# Altium schematic sheet S4V_ToF_Sensor_Board_V1.0_Schematic.SchDoc
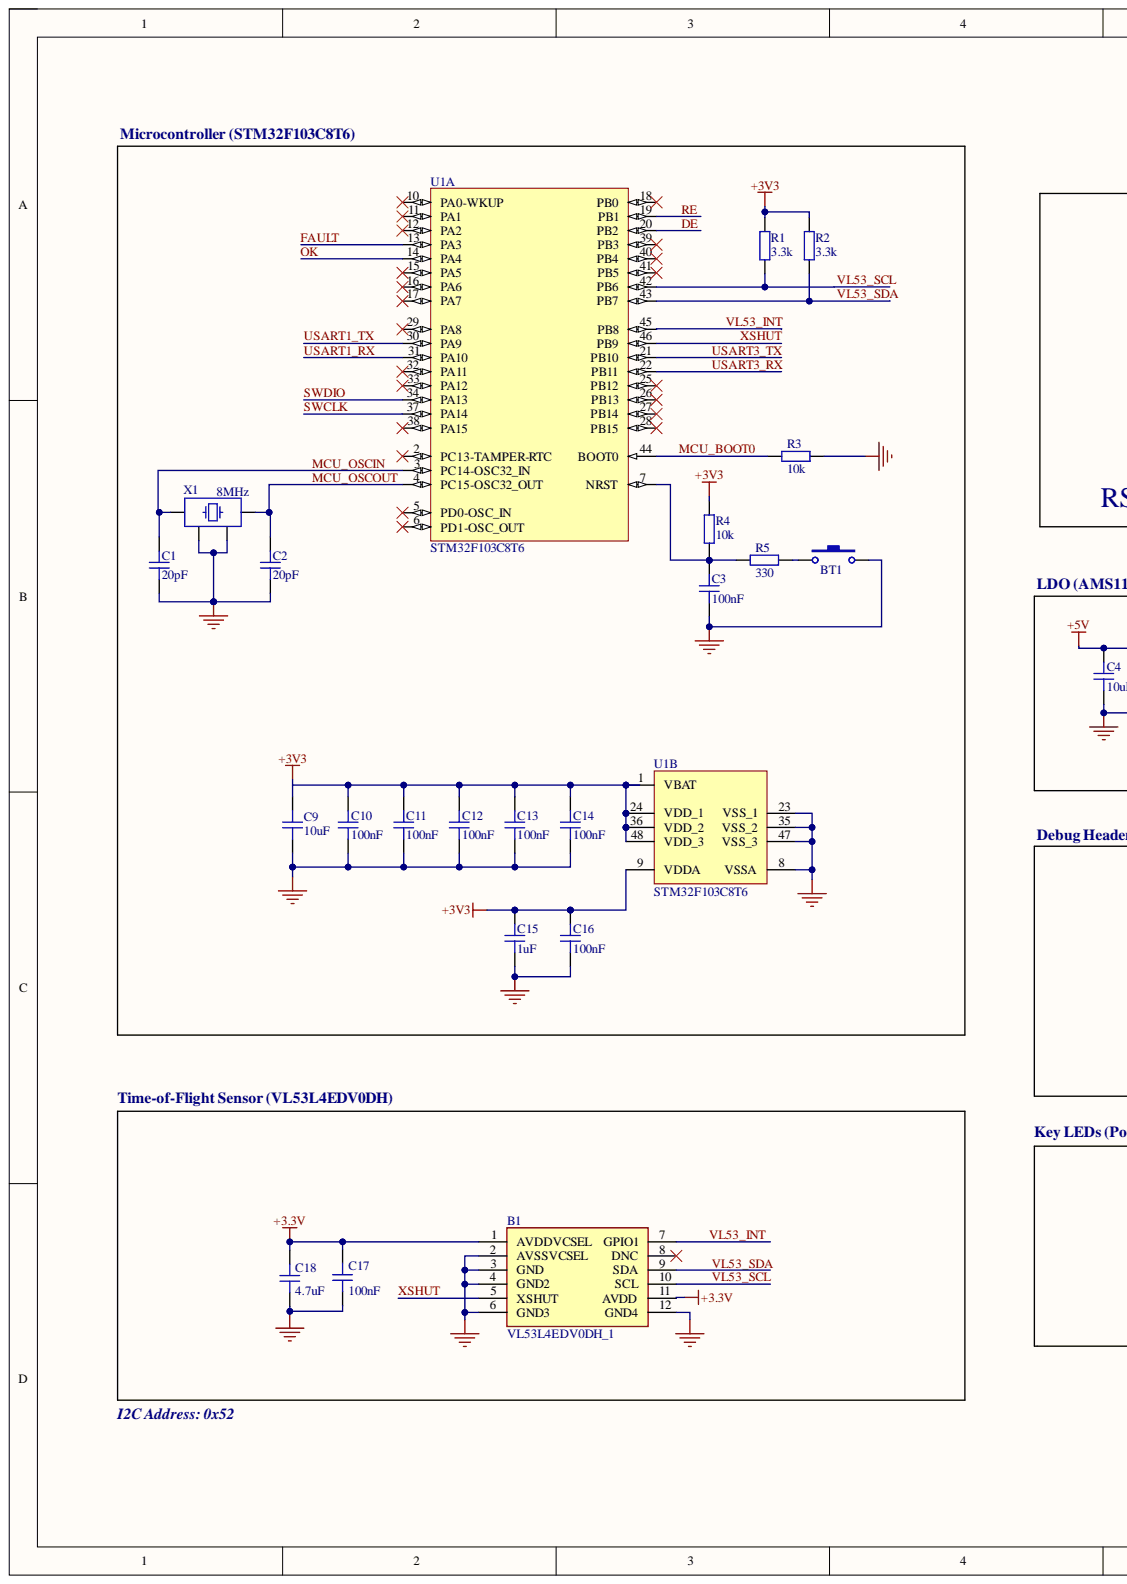
[diagram p0: S4V_ToF_Sensor_Board_V1.0_Schematic.SchDoc, left]
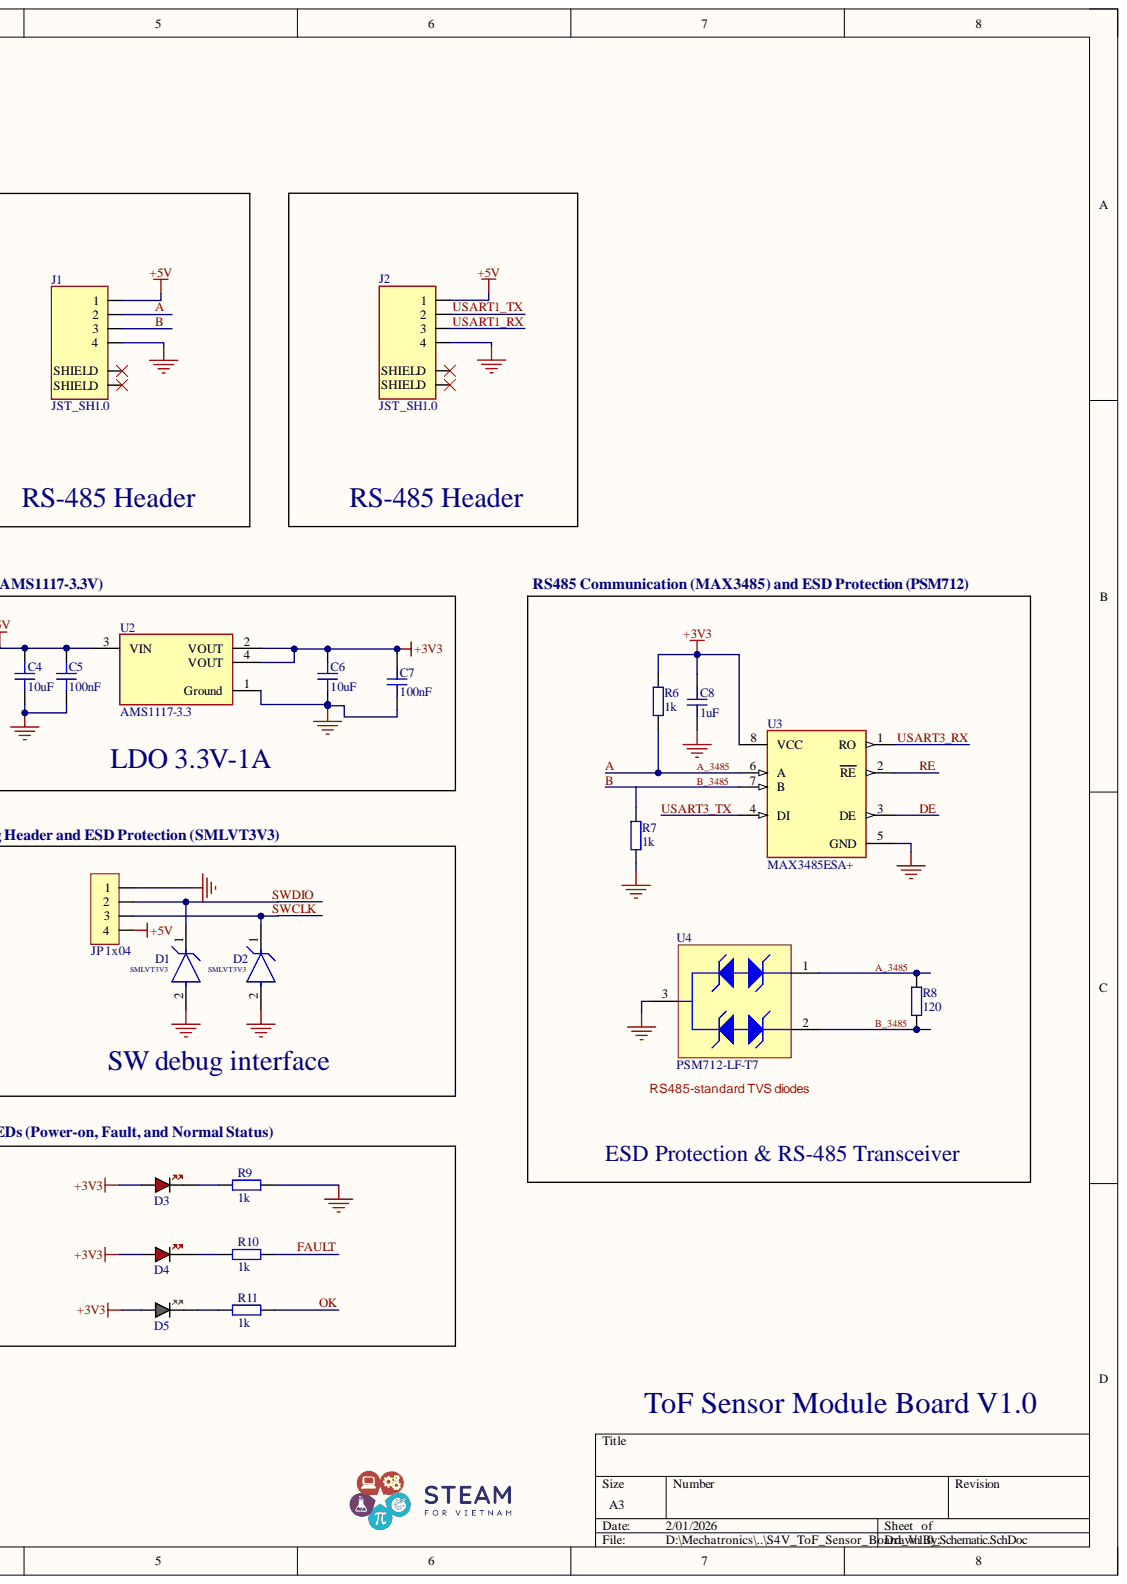
[diagram p1: S4V_ToF_Sensor_Board_V1.0_Schematic.SchDoc, right]

## Parts
B1  VL53L4EDV0DH_1  [VL53L4EDV0DH1]
BT1  Nut Nhan 2 Chan SMD 3x6x2.5  [Nut Nhan 2 Chan SMD 3x6x2.5]  (value not drawn)
C1  20pF  [CAP_0603]
C2  20pF  [CAP_0603]
C3  100nF  [CAP_0603]
C4  10uF  [CAP_0603]
C5  100nF  [CAP_0603]
C6  10uF  [CAP_0603]
C7  100nF  [CAP_0603]
C8  1uF  [CAP_0603]
C9  10uF  [CAP_0603]
C10  100nF  [CAP_0603]
C11  100nF  [CAP_0603]
C12  100nF  [CAP_0603]
C13  100nF  [CAP_0603]
C14  100nF  [CAP_0603]
C15  1uF  [CAP_0603]
C16  100nF  [CAP_0603]
C17  100nF  [CAP_0603]
C18  4.7uF  [CAP_0603]
D1  SMLVT3V3  [FP-SMB-MFG]
D2  SMLVT3V3  [FP-SMB-MFG]
D3  RED  [LED_0603_RED]  (value not drawn)
D4  RED  [LED_0603_RED]  (value not drawn)
D5  GREEN  [LED_0603_GREEN]  (value not drawn)
J1  JST_SH1.0  [JST_SM04B-SRSS-TB(LF)(SN)]
J2  JST_SH1.0  [JST_SM04B-SRSS-TB(LF)(SN)]
JP1  JP 1x04  [JP 1x4 DT]
R1  3.3k  [RES_0603]
R2  3.3k  [RES_0603]
R3  10k  [RES_0603]
R4  10k  [RES_0603]
R5  330  [RES_0603]
R6  1k  [RES_0603]
R7  1k  [RES_0603]
R8  120  [RES_0603]
R9  1k  [RES_0603]
R10  1k  [RES_0603]
R11  1k  [RES_0603]
U1  STM32F103C8T6  [STM-LQFP48_L]  (parts A,B)
U2  AMS1117-3.3  [FP-AMS1117-IPC_C]
U3  MAX3485ESA+  [FP-S8-5-MFG]
U4  PSM712-LF-T7  [PRKT-SOT23-3_V]
X1  8MHz  [XTAL_3225]

## Nets
+3.3V: B1.1(AVDDVCSEL) B1.11(AVDD) C17.2 C18.2
+3V3: C6.2 C7.2 C8.2 C9.2 C10.2 C11.2 C12.2 C13.2 C14.2 C15.2 C16.2 D3.A D4.A D5.A R1.2 R2.2 R4.2 R6.1 U1.1(VBAT) U1.9(VDDA) U1.24(VDD_1) U1.36(VDD_2) U1.48(VDD_3) U2.2(VOUT) U2.4(VOUT) U3.8(VCC)
+5V: C4.2 C5.2 J1.1 J2.1 JP1.4 U2.3(VIN)
GND: B1.2(AVSSVCSEL) B1.3(GND) B1.4(GND2) B1.6(GND3) B1.12(GND4) BT1.2 C1.1 C2.1 C3.1 C4.1 C5.1 C6.1 C7.1 C8.1 C9.1 C10.1 C11.1 C12.1 C13.1 C14.1 C15.1 C16.1 C17.1 C18.1 D1.2 D2.2 J1.4 J2.4 JP1.1 R3.2 R7.1 R9.2 U1.8(VSSA) U1.23(VSS_1) U1.35(VSS_2) U1.47(VSS_3) U2.1(Ground) U3.5(GND) U4.3 X1.2 X1.4
A: J1.2 R6.2 R8.1 U3.6(A) U4.1
B: J1.3 R7.2 R8.2 U3.7(B) U4.2
DE: U1.20(PB2) U3.3(DE)
FAULT: R10.2 U1.13(PA3)
MCU_BOOT0: R3.1 U1.44(BOOT0)
MCU_OSCIN: C1.2 U1.3(PC14-OSC32_IN) X1.1
MCU_OSCOUT: C2.2 U1.4(PC15-OSC32_OUT) X1.3
OK: R11.2 U1.14(PA4)
RE: U1.19(PB1) U3.2(RE)
SWCLK: D2.1 JP1.3 U1.37(PA14)
SWDIO: D1.1 JP1.2 U1.34(PA13)
USART1_RX: J2.3 U1.31(PA10)
USART1_TX: J2.2 U1.30(PA9)
USART3_RX: U1.22(PB11) U3.1(RO)
USART3_TX: U1.21(PB10) U3.4(DI)
VL53_INT: B1.7(GPIO1) U1.45(PB8)
VL53_SCL: B1.10(SCL) R1.1 U1.42(PB6)
VL53_SDA: B1.9(SDA) R2.1 U1.43(PB7)
XSHUT: B1.5(XSHUT) U1.46(PB9)
NetBT1_1: BT1.1 R5.2
NetC3_2: C3.2 R4.1 R5.1 U1.7(NRST)
NetD3_K: D3.K R9.1
NetD4_K: D4.K R10.1
NetD5_K: D5.K R11.1

Not connected: B1 8(DNC); J1 S1(SHIELD) S2(SHIELD); J2 S1(SHIELD) S2(SHIELD); U1 2(PC13-TAMPER-RTC) 5(PD0-OSC_IN) 6(PD1-OSC_OUT) 10(PA0-WKUP) 11(PA1) 12(PA2) 15(PA5) 16(PA6) 17(PA7) 18(PB0) 25(PB12) 26(PB13) 27(PB14) 28(PB15) 29(PA8) 32(PA11) 33(PA12) 38(PA15) 39(PB3) 40(PB4) 41(PB5)

## Library data of the parts
B1 = VL53L4EDV0DH_1: Time-of-Flight high accuracy proximity sensor with extended temperature capability; Manufacturer_Name: STMicroelectronics; Manufacturer_Part_Number: VL53L4EDV0DH/1; Geometry.Height: 1.05mm; Component Kind: Standard; Datasheet Link: https://www.st.com/resource/en/datasheet/vl53l4ed.pdf; Library Name: VL53L4EDV0DH_1.SchLib; Mouser Part Number: 511-VL53L4EDV0DH/1; Mouser Price/Stock: https://www.mouser.co.uk/ProductDetail/STMicroelectronics/VL53L4EDV0DH-1?qs=rQFj71Wb1eXc79Ux5WAGSg%3D%3D
BT1 = Nut Nhan 2 Chan SMD 3x6x2.5: Ma_Hang: MH001036; Ten: Nút Nhấn 2 Chân 3x6x2.5MM SMD; Component Kind: Standard; Description: Nut Nhan 2 Chan SMD 3x6x2.5; Library Name: Altium_Lib_by_KhueNguyenCreator.IntLib
C1-C18 = CAP_0603: Capacitor SMD 0603; Component Kind: Standard; Library Name: Schematic-Lib-PhilsLab.SchLib
D1 D2 = CMP-2000-07127-2: TVS DIODE 3.3V 10.3V SMB; Manufacturer: STMicroelectronics; Breakdown Voltage: 4.1V; Capacitance: 5200pF; Clamping Voltage: 7.3V; Direction: Unidirectional; Max Junction Temp: +175°C; Number Of Channels: 1; Peak Pulse Current: 50A; Peak Pulse Power: 600W; Standoff Height: 0.05mm; Value: 5200pF; Automotive: No; Category: Diode; Component Kind: Standard; ComponentLink2Description: Datasheet; ComponentLink2URL: https://www.st.com/resource/en/datasheet/smlvt3v3.pdf; Device Class L1: Circuit Protection; Device Class L2: TVS Diodes; Device Class L3: unset; Digikey Description: TVS DIODE 3.3V 10.3V SMB; Height: 2.65mm; Lead Free: Yes; Library Name: Altium Content Vault; Manufacturer Part Number: SMLVT3V3; Mouser Description: TVS Diodes - Transient Voltage Suppressors 600W 3.3V Unidirect; Octopart: https://octopart.com/smlvt3v3-stmicroelectronics-347049; Package: SMB; RoHS: TRUE; Temperature: -55°C to +175°C; Temperature Range High: +175°C; Temperature Range Low: -55°C
D3 D4 = LED_0603_RED: LED 0603 RED SMD; Component Kind: Standard; Library Name: Schematic-Lib-PhilsLab.SchLib
D5 = LED_0603_GREEN: LED 0603 GREEN SMD; Component Kind: Standard; Library Name: Schematic-Lib-PhilsLab.SchLib
J1 J2 = CMP-002-00071-1: Connector Header Surface Mount, Right Angle 4 position 0.039 (1.00mm); MF: JST Sales; MP: SM04B-SRSS-TB(LF)(SN); Case/Package: None; Check_prices: https://www.snapeda.com/parts/SM04B-SRSS-TB(LF)(SN)/JST/view-part/?ref=eda; Component Kind: Standard; Component Type: Standard; Design Item ID: SM04B-SRSS-TB(LF)(SN); Pins: 6; SnapEDA_Link: https://www.snapeda.com/parts/SM04B-SRSS-TB(LF)(SN)/JST/view-part/?ref=snap; Source: SM04B-SRSS-TB(LF)(SN).SchLib; Library Name: Manh Vu Tien
JP1 = JP 1x4 2.54 Duc Thang: Cắm; Don_Vi: Con; Ma_Hang: MH000903; Ten_Linh_Kien: Jump Đơn Đực 2.54MM 1x40P Thẳng 11MM; Chung_Loai: Cắm; Component Kind: Standard; Library Name: Altium_Lib_by_KhueNguyenCreator.IntLib
R1-R11 = RES_0603: Resistor SMD 0603; Component Kind: Standard; Library Name: Schematic-Lib-PhilsLab.SchLib
U1 = CMP-0237-00215-3: ARM Cortex-M3 32-bit MCU, 64 KB Flash, 20 KB Internal RAM, 37 I/Os, 48-pin LQFP, -40 to 85 degC, Tray; A/D Converter: 2x12-bit (10 Channel); FLASH Size (Prog) (kB): 64; I/Os (High Current): 37; Internal RAM Size (kB): 20; Operating Frequency(F) (Processor speed) (MHz): 72; Supply Voltage(Vcc) min (V): 2; Component Kind: Standard; ComponentLink1Description: Manufacturer URL; ComponentLink1URL: http://www.st.com/web/en/home.html; ComponentLink2Description: Datasheet; ComponentLink2URL: http://www.st.com/st-web-ui/static/active/en/resource/technical/document/datasheet/CD00161566.pdf; ComponentLink3Description: Package Specification; ComponentLink3URL: http://www.st.com/st-web-ui/static/active/en/resource/technical/document/datasheet/DM00058181.pdf; Core: ARM Cortex-M3; DatasheetVersion: Rev. 16; Library Name: Altium Content Vault; Mounting Technology: Surface Mount; PackageDescription: 48-Pin Low Profile Quad Flat Package, 7 x 7 mm Body, 0.5 mm Pitch; PackageReference: LQFP48; PackageVersion: Rev. 6, 06/2013; Packing: Tray; PartNumber: STM32F103C8T6
U2 = CMP-209535-000001-1: LDO Voltage Regulators 1A, 3.3V; Manufacturer: Advanced Monolithic Systems; Dropout Voltage: 1.1V; Max Junction Temp: +125°C; Max Power Dissipation: 1.2W; Max Supply Voltage: 15V; Nominal Supply Current: 5mA; Number Of Outputs: 1; Output Type: Fixed; Standoff Height: 0.64mm; Automotive: No; Category: IC; Component Kind: Standard; ComponentLink2Description: Datasheet; ComponentLink2URL: http://www.advanced-monolithic.com/pdf/ds1117.pdf; Device Class L1: Integrated Circuits (ICs); Device Class L2: Power Management ICs; Device Class L3: Voltage Regulators - Linear; Height: 1.8mm; Ipc Land Pattern Name: SOT229P700X180-4; Lead Free: Yes; Library Name: Altium Content Vault; Manufacturer Part Number: AMS1117-3.3; Octopart: https://octopart.com/ams1117-3.3-advanced+monolithic+systems-25899300; Output Current: 1A; Output Voltage: 3.3V; Package: AMS1117; RoHS: TRUE; Temperature: -40°C to +125°C; Temperature Range High: +125°C; Temperature Range Low: -40°C
U3 = CMP-05679-000038-1: IC TXRX RS485/422 10MBPS 8SOIC; Manufacturer: Maxim Integrated; Manufacturer Part Number: MAX3485EESA+; Data Rate: 15Mbits/s; Interface: RS-485,RS-422; Max Supply Voltage: 3.6V; Min Supply Voltage: 3V; Nominal Supply Current: 0.95-1.1mA; Number Of Receivers: 1; Simplex Duplex: Half Duplex; Standoff Height: 0.1mm; Automotive: No; Category: IC; Component Kind: Standard; ComponentLink2Description: Datasheet; ComponentLink2URL: https://datasheets.maximintegrated.com/en/ds/MAX3483E-MAX3491E.pdf; Device Class L1: Integrated Circuits (ICs); Device Class L2: Interface ICs; Device Class L3: RS-232 / RS-422 / RS-485 Interface ICs; Digikey Description: IC TXRX RS485/422 10MBPS 8SOIC; Footprint Url: https://pdfserv.maximintegrated.com/land_patterns/90-0096.PDF; Height: 1.75mm; Lead Free: Yes; Library Name: Altium Content Vault; Mouser Description: RS-422/RS-485 Interface IC 3.3V 12Mbps Transceiver; Number Of Transmitters: 1; Octopart: https://octopart.com/max3485eesa%2B-maxim+integrated-39731903; Package: S8-5; RoHS: TRUE; Temperature: -40°C to +85°C; Temperature Range High: +85°C; Temperature Range Low: -40°C
U4 = CMP-2000-07553-1: TVS Array - Asymmetrical Data Line Protector, 600 W, 7.5 V, -55 to 150 degC, 3-Pin SOT23, RoHS, Tape and Reel; Manufacturer: Protek Devices; Capacitance: 75pF; Case\/Package: SOT-23; Clamping Voltage: 17V; Current: 20uA; Direction: Bidirectional; Element Configuration: Dual; Min Breakdown Voltage: 7.5V; Power Dissipation: 600W; Voltage: 12V; Working Voltage: 7V; Component Kind: Standard; Datasheet URL: www.protekdevices.com/xyz/documents/datasheets/psm712.pdf; Datasheet Version: 10/2013; Depth: 1.4mm; Lead Free: LeadFree; Leakage Current: 20uA; Length: 3.04mm; Library Name: Altium Content Vault; Manufacturer URL: http://www.protekdevices.com/; Max Operating Temperature: 150degC; Min Operating Temperature: -55degC; Mounting Technology: SurfaceMount; Number of Pins: 3; Package Description: 3-Pin Small Outline Transistor, Body 2.92 x 1.3 mm, Pitch 0.94 mm; Package Reference: SOT23-3; Packaging: TapeandReel; Radiation Hardening: No; RoHSCompliant: True
X1 = TSX-3225_24.0000MF15X-AC: Crystal SMD 24MHz 10PPM 7pF 3225; Manufacturer: Epson; Manufacturer Part Number: TSX-3225 24.0000MF15X-AC; Component Kind: Standard; Library Name: Schematic-Lib-PhilsLab.SchLib
